# Altium schematic sheet MISKO_V2_4.SchDoc
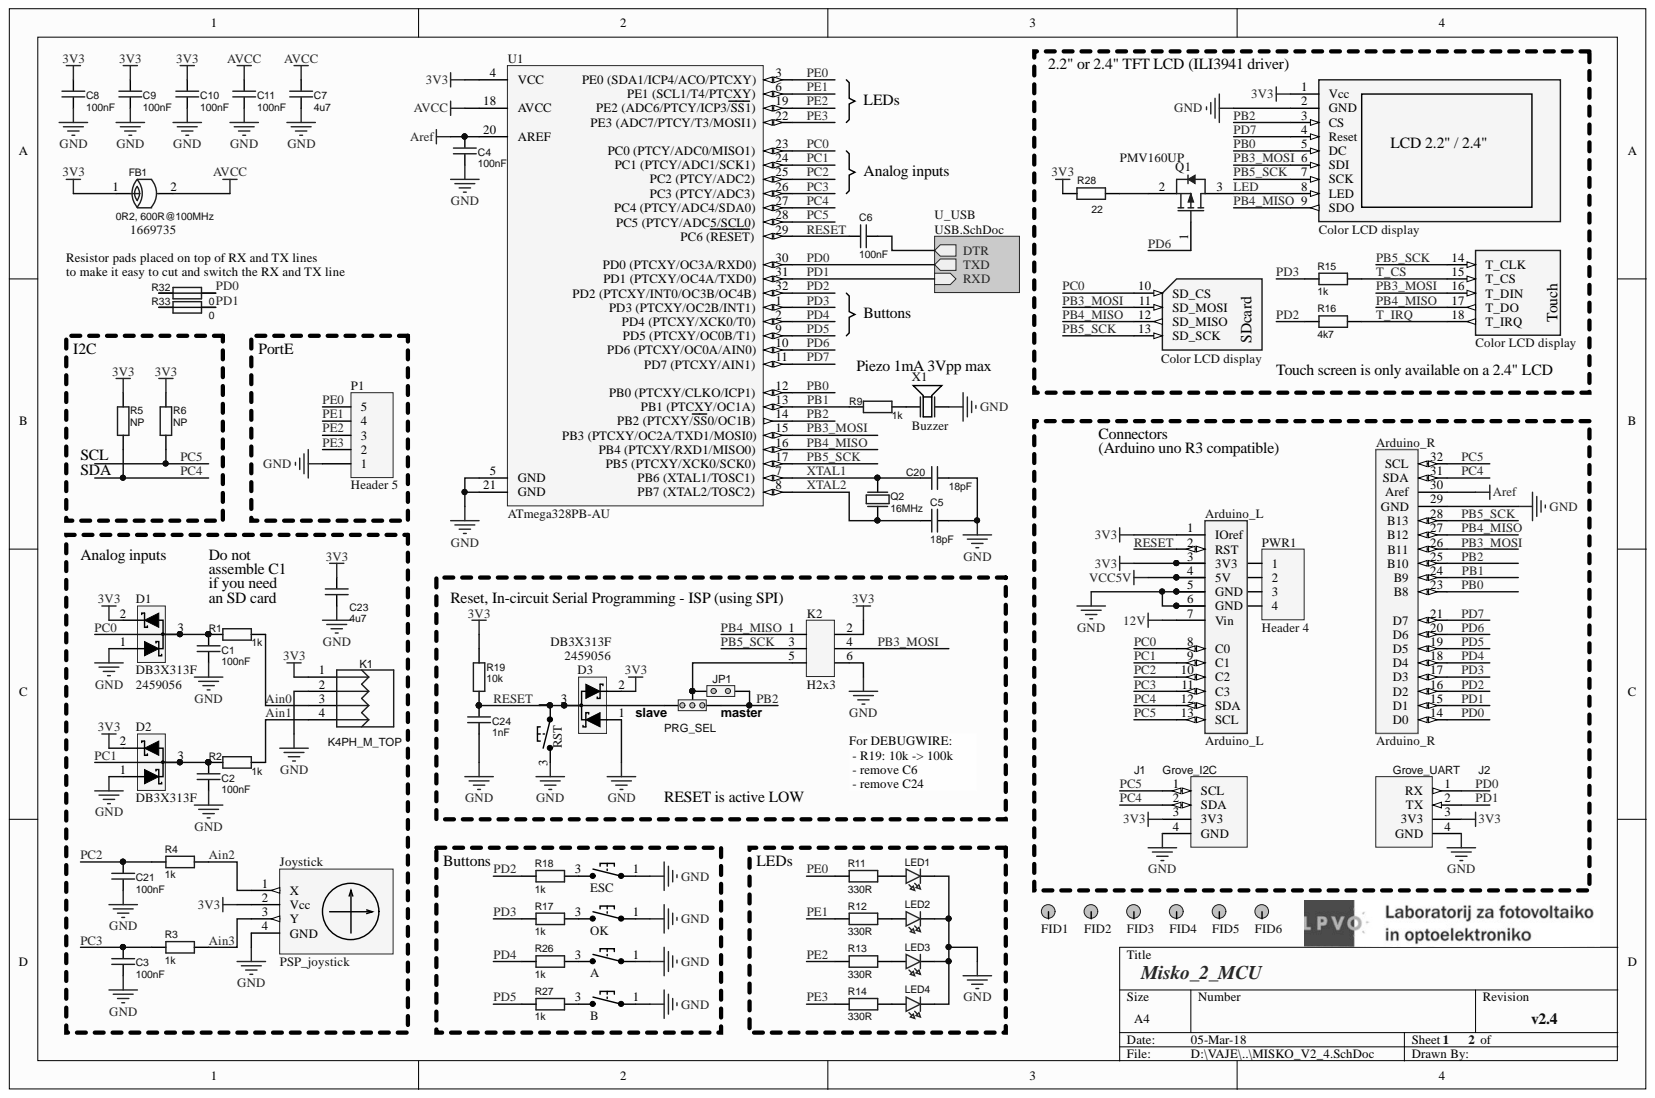
[diagram p0: MISKO_V2_4.SchDoc]

## Parts
A  Tipka_6x6  [Tipka 6x6]  (value not drawn)
Arduino_L  Arduino_L  [Arduino_L]
Arduino_R  Arduino_R  [Arduino_R]
B  Tipka_6x6  [Tipka 6x6]  (value not drawn)
C1  100nF  [C0805]
C2  100nF  [C0805]
C3  100nF  [C0805]
C4  100nF  [C0805]
C5  18pF  [C0805]
C6  100nF  [C0805]
C7  4u7  [C0805]
C8  100nF  [C0805]
C9  100nF  [C0805]
C10  100nF  [C0805]
C11  100nF  [C0805]
C20  18pF  [C0805]
C21  100nF  [C0805]
C23  4u7  [C0805]
C24  1nF  [C0805]
D1  DB3X313F  Supplier Part Number 1: 2459056  [SOT23]
D2  DB3X313F  [SOT23]
D3  DB3X313F  Supplier Part Number 1: 2459056  [SOT23]
ESC  Tipka_6x6  [Tipka 6x6]  (value not drawn)
FB1  value 0R2, 600R@100MHz  Supplier Part Number 1: 1669735  [R0805]  (hidden comment: FB_0R2)
FID1  Fiducial  [Fiducial]  (value not drawn)
FID2  Fiducial  [Fiducial]  (value not drawn)
FID3  Fiducial  [Fiducial]  (value not drawn)
FID4  Fiducial  [Fiducial]  (value not drawn)
FID5  Fiducial  [Fiducial]  (value not drawn)
FID6  Fiducial  [Fiducial]  (value not drawn)
J1  Grove_I2C  [Groove]
J2  Grove_UART  [Groove]
Joystick  PSP_joystick  [PSP1000JOY]
JP1  JMP  [solderJumper]  (value not drawn)
K1  K4PH_M_TOP  [K4PH_M_TOP]
K2  H2x3  [H2X3_SPEEDY]
LCD  Color LCD display  [LCD_display]  (parts A,B,C)
LED1  GREEN  [LED0805G]  (value not drawn)
LED2  GREEN  [LED0805G]  (value not drawn)
LED3  GREEN  [LED0805G]  (value not drawn)
LED4  GREEN  [LED0805G]  (value not drawn)
OK  Tipka_6x6  [Tipka 6x6]  (value not drawn)
P1  Header 5  [HDR1X5]
PRG_SEL  JMP3  [H1x3]  (value not drawn)
PWR1  Header 4  [HDR1X4]
Q1  PMV160UP  [NXP-SOT23_N]
Q2  16MHz  [Crystal_HC49]
R1  1k  [R0805]
R2  1k  [R0805]
R3  1k  [R0805]
R4  1k  [R0805]
R5  NP  [R0805]
R6  NP  [R0805]
R9  1k  [R0805]
R11  330R  [R0805]
R12  330R  [R0805]
R13  330R  [R0805]
R14  330R  [R0805]
R15  1k  [R0805]
R16  4k7  [R0805]
R17  1k  [R0805]
R18  1k  [R0805]
R19  10k  [R0805]
R26  1k  [R0805]
R27  1k  [R0805]
R28  22  [R0805]
R32  0  [R0603]
R33  0  [R0603]
RST  Tipka_6x6  [Tipka 6x6]  (value not drawn)
U1  ATmega328PB-AU  [32A_N]
X1  Buzzer  [Buzzer]

## Nets
3V3: Arduino_L.1(IOref) Arduino_L.3(3V3) C8.2 C9.2 C10.2 C23.1 D1.2 D2.2 D3.2 FB1.1 J1.3(3V3) J2.3(3V3) Joystick.2(Vcc) K1.1 K2.2 LCD.1(Vcc) PWR1.1 R5.2 R6.2 R19.1 R28.1 U1.4(VCC)
12V: Arduino_L.7(Vin)
Aref: Arduino_R.30(Aref) C4.2 U1.20(AREF)
AVCC: C7.1 C11.2 FB1.2 U1.18(AVCC)
GND: A.1 Arduino_L.5(GND) Arduino_L.6(GND) Arduino_R.29(GND) B.1 C1.2 C2.2 C3.2 C4.1 C5.1 C7.2 C8.1 C9.1 C10.1 C11.1 C20.1 C21.2 C23.2 C24.1 D1.1 D2.1 D3.1 ESC.1 J1.4(GND) J2.4(GND) Joystick.4(GND) K1.2 K2.6 LCD.2(GND) LCD.M0 LED1.K LED2.K LED3.K LED4.K OK.1 P1.1 PWR1.3 PWR1.4 RST.3 U1.5(GND) U1.21(GND) X1.2
VCC5V: Arduino_L.4(5V) PWR1.2
Ain0: K1.3 R1.1
Ain1: K1.4 R2.1
Ain2: Joystick.1(X) R4.1
Ain3: Joystick.3(Y) R3.1
LED: LCD.8(LED) Q1.3
PB0: Arduino_R.23(B8) LCD.5(DC) U1.12(PB0_(PTCXY/CLKO/ICP1))
PB1: Arduino_R.24(B9) R9.1 U1.13(PB1_(PTCXY/OC1A))
PB2: Arduino_R.25(B10) JP1.1 LCD.3(CS) PRG_SEL.1 U1.14(PB2_(PTCXY/SS0/OC1B))
PB3_MOSI: Arduino_R.26(B11) K2.4 LCD.6(SDI) LCD.11(SD_MOSI) LCD.16(T_DIN) U1.15(PB3_(PTCXY/OC2A/TXD1/MOSI0))
PB4_MISO: Arduino_R.27(B12) K2.1 LCD.9(SDO) LCD.12(SD_MISO) LCD.17(T_DO) U1.16(PB4_(PTCXY/RXD1/MISO0))
PB5_SCK: Arduino_R.28(B13) K2.3 LCD.7(SCK) LCD.13(SD_SCK) LCD.14(T_CLK) U1.17(PB5_(PTCXY/XCK0/SCK0))
PC0: Arduino_L.8(C0) C1.1 D1.3 LCD.10(SD_CS) R1.2 U1.23(PC0_(PTCY/ADC0/MISO1))
PC1: Arduino_L.9(C1) C2.1 D2.3 R2.2 U1.24(PC1_(PTCY/ADC1/SCK1))
PC2: Arduino_L.10(C2) C21.1 R4.2 U1.25(PC2_(PTCY/ADC2))
PC3: Arduino_L.11(C3) C3.1 R3.2 U1.26(PC3_(PTCY/ADC3))
PC4: Arduino_L.12(SDA) Arduino_R.31(SDA) J1.2(SDA) R5.1 U1.27(PC4_(PTCY/ADC4/SDA0))
PC5: Arduino_L.13(SCL) Arduino_R.32(SCL) J1.1(SCL) R6.1 U1.28(PC5_(PTCY/ADC5/SCL0))
PD0: Arduino_R.14(D0) J2.1(RX) R32.1 R32.2 U1.30(PD0_(PTCXY/OC3A/RXD0))
PD1: Arduino_R.15(D1) J2.2(TX) R33.1 R33.2 U1.31(PD1_(PTCXY/OC4A/TXD0))
PD2: Arduino_R.16(D2) R16.1 R18.1 U1.32(PD2_(PTCXY/INT0/OC3B/OC4B))
PD3: Arduino_R.17(D3) R15.1 R17.1 U1.1(PD3_(PTCXY/OC2B/INT1))
PD4: Arduino_R.18(D4) R26.1 U1.2(PD4_(PTCXY/XCK0/T0))
PD5: Arduino_R.19(D5) R27.1 U1.9(PD5_(PTCXY/OC0B/T1))
PD6: Arduino_R.20(D6) Q1.1 U1.10(PD6_(PTCXY/OC0A/AIN0))
PD7: Arduino_R.21(D7) LCD.4(Reset) U1.11(PD7_(PTCXY/AIN1))
PE0: P1.5 R11.1 U1.3
PE1: P1.4 R12.1 U1.6(PE1_(SCL1/T4/PTCXY))
PE2: P1.3 R13.1 U1.19
PE3: P1.2 R14.1 U1.22(PE3_(ADC7/PTCY/T3/MOSI1))
RESET: Arduino_L.2(RST) C6.2 C24.2 D3.3 PRG_SEL.2 R19.2 RST.1 U1.29(PC6_(RESET))
T_CS: LCD.15(T_CS) R15.2
T_IRQ: LCD.18(T_IRQ) R16.2
XTAL1: C20.2 Q2.1 U1.7(PB6_(XTAL1/TOSC1))
XTAL2: C5.2 Q2.2 U1.8(PB7_(XTAL2/TOSC2))
NetA_3: A.3 R26.2
NetB_3: B.3 R27.2
NetC6_1: C6.1
NetESC_3: ESC.3 R18.2
NetFID1_F: FID1.F
NetFID2_F: FID2.F
NetFID3_F: FID3.F
NetFID4_F: FID4.F
NetFID5_F: FID5.F
NetFID6_F: FID6.F
NetJP1_2: JP1.2 K2.5 PRG_SEL.3
NetLED1_A: LED1.A R11.2
NetLED2_A: LED2.A R12.2
NetLED3_A: LED3.A R13.2
NetLED4_A: LED4.A R14.2
NetOK_3: OK.3 R17.2
NetQ1_2: Q1.2 R28.2
NetR9_2: R9.2 X1.1

## Library data of the parts
A B ESC OK RST = Tipka_6x6: tactile switch SMD; Component Kind: Standard; Library Name: MISKO V2_1.IntLib
C1-C11 C20 C21 C23 C24 = C: Capacitor; Component Kind: Standard; Library Name: EMC_FE_2016.IntLib
  C1-C4 C6 C8-C11 C21: Value: 100nF
  C5 C20: Value: 18pF
  C7 C23: Value: 4u7
  C24: Value: 1nF
D1-D3 = DB3X313F: Schottky; Component Kind: Standard; Supplier 1: Farnell; Library Name: MISKO V2_1.IntLib
  D2: Supplier Part Number 1: 2459056
FB1 = FERITTE BEAD: Component Kind: Standard; Description: FERITTE BEAD; Library Name: EMC_FE_2016.IntLib; Supplier 1: Farnell
FID1-FID6 = Fiducial: according to IPC-7351 - is a 1mm diameter round dot with 2mm solder mask opening; max.Temperature: +125; min.Temperature: -40; Tolerance: 5%; Voltage: 50V; Component Kind: Standard; Library Name: EMC_FE_2016.SCHLIB
J1 = Groove_I2C: Groove connector; Value: {Value}; Component Kind: Standard; Library Name: MISKO V2_4_.IntLib
J2 = Groove_UART: Groove connector; Value: {Value}; Component Kind: Standard; Library Name: MISKO V2_4_.IntLib
JP1 = JMP: Value: {Value}; Component Kind: Standard; Description: JMP; Library Name: Kon_Tipk.IntLib
K1 = K4PH_M_TOP: Value: {Value}; Component Kind: Standard; Description: K4PH_M_TOP; Library Name: Kon_Tipk.IntLib
K2 = H2x3: Header 2x3 (male); Component Kind: Standard; Library Name: MISKO V2.intlib
LCD = LCD_module: 2.2" or 2.4" LCD_module w/o Touch; Component Kind: Standard; Library Name: MISKO V2_4_.IntLib
LED1-LED4 = LED: Value: {Value}; Component Kind: Standard; Description: LED; Library Name: MISKO V2.intlib
P1 = Header 5: Header, 5-Pin; Component Kind: Standard; LatestRevisionDate: 17-Jul-2002; LatestRevisionNote: Re-released for DXP Platform.; Library Name: Miscellaneous Connectors.IntLib; Signal Integrity: Connector; Publisher: Altium Limited
PRG_SEL = JMP3: Value: {Value}; Component Kind: Standard; Description: JMP3; Library Name: MISKO V2.intlib
PWR1 = Header 4: Header, 4-Pin; Component Kind: Standard; LatestRevisionDate: 17-Jul-2002; LatestRevisionNote: Re-released for DXP Platform.; Library Name: Miscellaneous Connectors.IntLib; Signal Integrity: Connector; Publisher: Altium Limited
Q1 = PMV160UP,215: P-Channel Trench MOSFET, -20 V, -1.2 A, -55 to 150 degC, 3-Pin SOT23, Tape and Reel; Manufacturer: NXP Semiconductors; Channel Type: P; Ciss [typ] (pF): 365; Coss [typ] (pF): 42; Packing: Tape & Reel; VGSth [typ] (V): -0.7; Code_JEDEC: TO-236AB; Component Kind: Standard; ComponentLink1Description: Manufacturer URL; ComponentLink1URL: http://www.nxp.com/; ComponentLink2Description: Datasheet; ComponentLink2URL: http://www.nxp.com/documents/data_sheet/PMV160UP.pdf; ComponentLink3Description: Package Specification; ComponentLink3URL: http://www.nxp.com/documents/outline_drawing/sot023_po.pdf; DatasheetVersion: Rev. 2; ID [max] (A): -1.2; Library Name: NXP Semiconductors Standard MOSFETs.IntLib; Mounting Technology: Surface Mount; PackageDescription: 3-Pin Small Outline Transistor Package, Body 2.9 x 1.3 mm, Pitch 0.95 mm; PackageReference: SOT23; PackageVersion: 06-03-16, 03/2006; PartNumber: PMV160UP,215; Supplier 1: Digi-Key; Supplier 2: Digi-Key; Supplier 3: Digi-Key; Supplier 4: Newark; Supplier 5: Mouser; Supplier Part Number 1: 568-10320-2-ND; Supplier Part Number 2: 568-10320-1-ND; Supplier Part Number 3: 568-10320-6-ND; Supplier Part Number 4: 54T9404; Supplier Part Number 5: 771-PMV160UP215; VDS [max] (V): -20
Q2 = Crystal: Component Kind: Standard; Description: Crystal; Value: 16MHz; Library Name: MISKO V2.intlib
R1-R6 R9 R11-R19 R26-R28 R32 R33 = R: Component Kind: Standard; Description: R; Library Name: EMC_FE_2016.IntLib
  R1-R4 R9 R15 R17 R18 R26 R27: Value: 1k
  R5 R6: Value: NP
  R11-R14: Value: 330R
  R16: Value: 4k7
  R19: Value: 10k
  R28: Value: 22
  R32 R33: Value: 0
U1 = ATmega328PB-AU: 8-bit AVR Microcontroller, 32KB Flash, 1KB EEPROM, 2KB SRAM, 32-pin TQFP, Industrial Grade (-40°C to 85°C); Code_JEDEC: MS-026-ABA; Component Kind: Standard; ComponentLink1Description: Manufacturer URL; ComponentLink1URL: http://www.atmel.com/; ComponentLink2Description: ATmega328PB Datasheet; ComponentLink2URL: http://www.atmel.com/Images/Atmel-42397-8-bit-AVR-Microcontroller-ATmega328PB_Datasheet.pdf; ComponentLink3Description: ATmega328P Summary; ComponentLink3URL: http://www.atmel.com/Images/Atmel-42397-8-bit-AVR-Microcontroller-ATmega328PB_Summary.pdf; DatasheetVersion: revC, Oct-2015; PackageDescription: 32-Lead, 7x7x1.0 mm Body, 0.8mm Lead Pitch, Thin Profile Plastic Quad Flat Package (TQFP); PackageReference: 32A; Library Name: MISKO V2_4_.IntLib
X1 = Buzzer: Piezzo Buzzer; Manufacturer: MULTICOMP; Manufacturer Part Number: MCABT-458-RC; Current Rating: 1; Resonant Frequency: 4; Sound Level SPL: 75; Supply Voltage Max: 25; SVHC: No SVHC (15-Jun-2015)<!--FANDB--><div class="features-and-benefits-container"><div class="features-and-benefits-description"><span class="features-benefits-value">The MCABT-458-RC is a 1mA/25V Piezo Transducer Sounder with LCP housing, surface mount, single tone, 75dB sound level and 4kHz resonant frequency.</span></div><div class="features-and-benefits-list"><ul class="features-and-benefits-list-list"><li class="features-and-benefits-list-item">-30 to 70; Tones: Single; Transducer Function: Sounder; Transducer Mounting: SMD; Component Kind: Standard; ComponentLink1Description: http://www.farnell.com/datasheets/1860703.pdf; ComponentLink1URL: http://www.farnell.com/datasheets/1860703.pdf; Pricing 1: 1=1.47, 2=1.33, 3=1.22, 5=1.14, 10=0.949, 20=0.93, 50=0.911, 100=0.892 (GBP); Product Range: -; RoHS: Y-EX; Stock 1: 683; Supplier 1: Farnell; Supplier Part Number 1: 2433031; Supply Voltage Min: 1; Library Name: MISKO_V2.IntLib
Arduino_L = Arduino_L: Component Kind: Standard; Library Name: MISKO V2_4_.IntLib
Arduino_R = Arduino_R: Component Kind: Standard; Library Name: MISKO V2_4_.IntLib
Joystick = PSP_joystick: Component Kind: Standard; Library Name: MISKO V2_4_my.IntLib
